# Altium schematic sheet SWITCHES.SchDoc(SWITCHES)
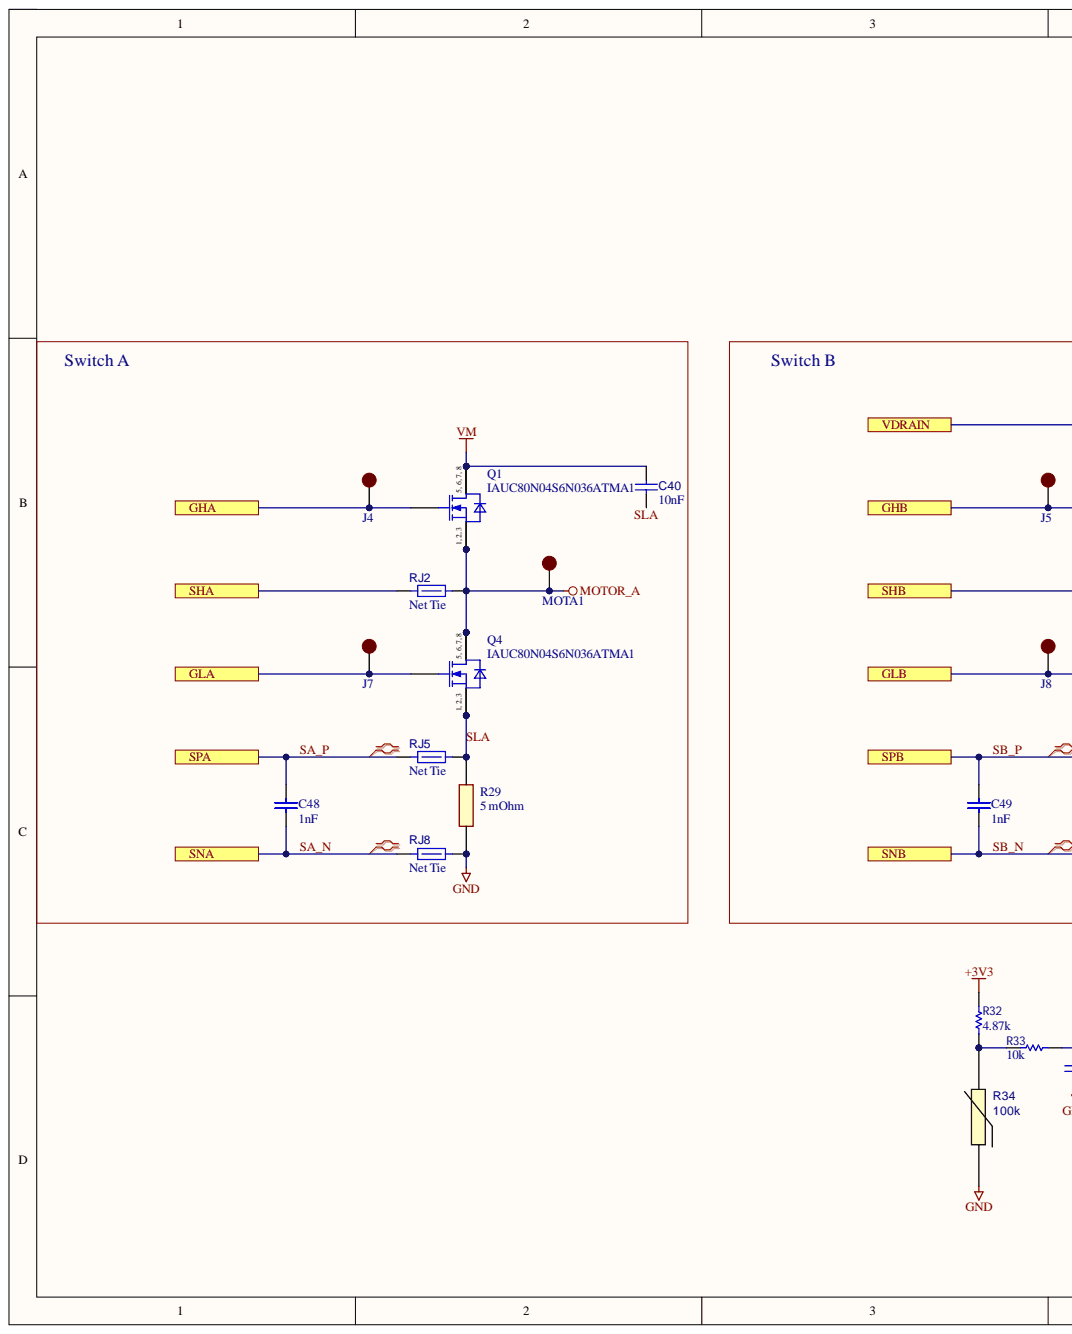
[diagram p0: SWITCHES.SchDoc, left]
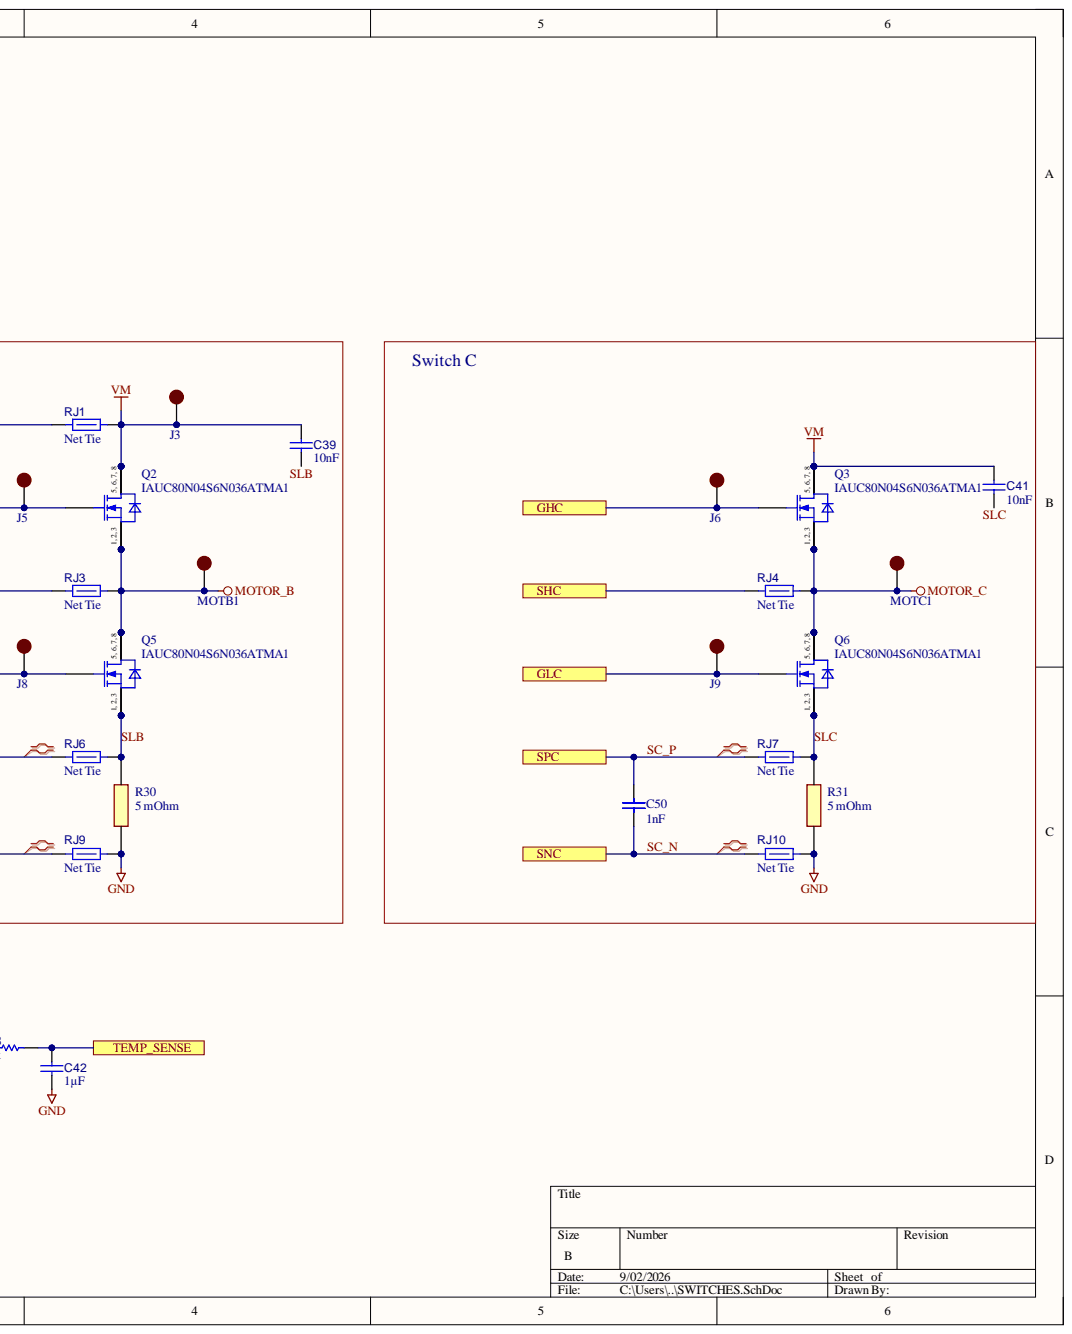
[diagram p1: SWITCHES.SchDoc, right]

## Parts
C39  10nF  [CAPC3216X190X55ML30T25]
C40  10nF  [CAPC3216X190X55ML30T25]
C41  10nF  [CAPC3216X190X55ML30T25]
C42  1µF  [0603_Capacitor]
C48  1nF  [C-0402]
C49  1nF  [C-0402]
C50  1nF  [C-0402]
J3  5000 TP  [FP-5000-MFG]  (value not drawn)
J4  5000 TP  [FP-5000-MFG]  (value not drawn)
J5  5000 TP  [FP-5000-MFG]  (value not drawn)
J6  5000 TP  [FP-5000-MFG]  (value not drawn)
J7  5000 TP  [FP-5000-MFG]  (value not drawn)
J8  5000 TP  [FP-5000-MFG]  (value not drawn)
J9  5000 TP  [FP-5000-MFG]  (value not drawn)
MOTA1  5000 TP  [FP-5000-MFG]  (value not drawn)
MOTB1  5000 TP  [FP-5000-MFG]  (value not drawn)
MOTC1  5000 TP  [FP-5000-MFG]  (value not drawn)
Q1  IAUC80N04S6N036ATMA1  [INF-PG-TDSON-8-33-V]
Q2  IAUC80N04S6N036ATMA1  [INF-PG-TDSON-8-33-V]
Q3  IAUC80N04S6N036ATMA1  [INF-PG-TDSON-8-33-V]
Q4  IAUC80N04S6N036ATMA1  [INF-PG-TDSON-8-33-V]
Q5  IAUC80N04S6N036ATMA1  [INF-PG-TDSON-8-33-V]
Q6  IAUC80N04S6N036ATMA1  [INF-PG-TDSON-8-33-V]
R29  5 mOhm  [RESC6432X80N]
R30  5 mOhm  [RESC6432X80N]
R31  5 mOhm  [RESC6432X80N]
R32  value 4.87k  [R-0402]  (hidden comment: Resistor 4.87k)
R33  value 10k  [R-0402]  (hidden comment: Resistor 10k)
R34  100k  [0603_RESISTOR]
RJ1  Net Tie  [NetTie, SMD, 10mil, FIXED]
RJ2  Net Tie  [NetTie, SMD, 10mil, FIXED]
RJ3  Net Tie  [NetTie, SMD, 10mil, FIXED]
RJ4  Net Tie  [NetTie, SMD, 10mil, FIXED]
RJ5  Net Tie  [NetTie, SMD, 10mil, FIXED]
RJ6  Net Tie  [NetTie, SMD, 10mil, FIXED]
RJ7  Net Tie  [NetTie, SMD, 10mil, FIXED]
RJ8  Net Tie  [NetTie, SMD, 10mil, FIXED]
RJ9  Net Tie  [NetTie, SMD, 10mil, FIXED]
RJ10  Net Tie  [NetTie, SMD, 10mil, FIXED]

## Ports
GHA, GHB, GHC, GLA, GLB, GLC, SHA, SHB, SHC, SNA, SNB, SNC, SPA, SPB, SPC, TEMP_SENSE, VDRAIN

## Nets
+3V3: R32.2
GND: C42.2 R29.1 R30.1 R31.1 R34.1 RJ8.2 RJ9.2 RJ10.2
MOTOR_A: MOTA1.1 Q1.1 Q1.2 Q1.3 Q4.5 Q4.6 Q4.7 Q4.8 RJ2.2
MOTOR_B: MOTB1.1 Q2.1 Q2.2 Q2.3 Q5.5 Q5.6 Q5.7 Q5.8 RJ3.2
MOTOR_C: MOTC1.1 Q3.1 Q3.2 Q3.3 Q6.5 Q6.6 Q6.7 Q6.8 RJ4.2
VM: C39.2 C40.2 C41.2 J3.1 Q1.5 Q1.6 Q1.7 Q1.8 Q2.5 Q2.6 Q2.7 Q2.8 Q3.5 Q3.6 Q3.7 Q3.8 RJ1.2
GHA: J4.1 Q1.4 ; port GHA
GHB: J5.1 Q2.4 ; port GHB
GHC: J6.1 Q3.4 ; port GHC
GLA: J7.1 Q4.4 ; port GLA
GLB: J8.1 Q5.4 ; port GLB
GLC: J9.1 Q6.4 ; port GLC
SA_N: C48.2 RJ8.1 ; port SNA
SA_P: C48.1 RJ5.1 ; port SPA
SB_N: C49.2 RJ9.1 ; port SNB
SB_P: C49.1 RJ6.1 ; port SPB
SC_N: C50.2 RJ10.1 ; port SNC
SC_P: C50.1 RJ7.1 ; port SPC
SHA: RJ2.1 ; port SHA
SHB: RJ3.1 ; port SHB
SHC: RJ4.1 ; port SHC
SLA: C40.1 Q4.1 Q4.2 Q4.3 R29.2 RJ5.2
SLB: C39.1 Q5.1 Q5.2 Q5.3 R30.2 RJ6.2
SLC: C41.1 Q6.1 Q6.2 Q6.3 R31.2 RJ7.2
TEMP_SENSE: C42.1 R33.2 ; port TEMP_SENSE
VDRAIN: RJ1.1 ; port VDRAIN
NetR32_1: R32.1 R33.1 R34.2

## Library data of the parts
C39-C41 = CMP-001-00246-1: Ceramic Capacitor, Multilayer, Ceramic, 630V, 5% +Tol, 5% -Tol, C0G, 30ppm/Cel TC, 0.01uF, Surface Mount, 1206; Manufacturer: YAGEO; Manufacturer PN: CC1206JFNPOZBN103; Type: Capacitor C0G; Component Kind: Standard; ComponentLink1Description: Datasheet; ComponentLink1URL: https://www.yageo.com/en/Chart/Download/pdf/CC1206JFNPOZBN103; Library Name: BLDC_Symbols.SchLib; Package: 1206; Supplier 1: Digikey; Supplier Part Number 1: 13-CC1206JFNPOZBN103CT-ND; Tolerance: 5%; Value: 10nF; Voltage Rating: 630V
C42 = CMP-001-00209-4: 1 µF ±10% 25V Ceramic Capacitor X7R 0603 (1608 Metric); Manufacturer: Samsung Electro-Mechanics; Manufacturer PN: CL10B105KA8NNNC; Component Kind: Standard; ComponentLink1Description: Datasheet; ComponentLink1URL: https://mm.digikey.com/Volume0/opasdata/d220001/medias/docus/3644/CL10B105KA8NNNC_spec.pdf; Library Name: BLDC_Symbols.SchLib; Package: 0603; Supplier 1: Digikey; Supplier Part Number 1: 1276-1184-1-ND; Tolerance: 10%; Type: Capacitor X7R; Value: 1µF; Voltage Rating: 25V
C48-C50 = CAP, 1nF 0603 X7R: 1000 pF ±10% 50V Ceramic Capacitor X7R 0402 (1005 Metric); Manufacturer: YAGEO; Manufacturer PN: CC0402KRX7R9BB102; Tolerance: 5%; Component Kind: Standard; ComponentLink1Description: Datasheet; ComponentLink1URL: https://www.mouser.com/datasheet/3/508/1/KEM_C1002_X7R_SMD.pdf; Library Name: BLDC_Symbols.SCHLIB; Package: 0402; Supplier 1: Digikey; Supplier Part Number 1: 311-1036-1-ND; Type: Capacitor X7R; Value: 1nF; Voltage Rating: 50V
J3-J9 MOTA1 MOTB1 MOTC1 = CMP-00030-00018-2: Keystone 5000 Test Point ultra compact; Manufacturer PN: 5000; Component Kind: Standard; ComponentLink1Description: Datasheet; ComponentLink1URL: https://www.keyelco.com/userAssets/file/K75p62.pdf; Library Name: BLDC_Symbols.SchLib; Manufacturer: Keystone; Package: TH; Supplier 1: Digikey; Supplier Part Number 1: 36-5000-ND; Type: Test Point; Value: 5000 TP
Q1-Q6 = CMP-004-00064-1: Insulated-Gate Field-Effect Transistor (IGFET), N-Channel, Enhancement, Body Diode, Pin 1 Source, 2 Source, 3 Source, 4 Gate, 5 Drain, 6 Drain, 7 Drain, 8 Drain, 8 Pins; Manufacturer: Infineon Technologies; Current: 80A; Power: 50W; Type: Power MOSFET; Voltage Rating: 40V; Component Kind: Standard; ComponentLink1Description: Datasheet; ComponentLink1URL: https://www.infineon.com/assets/row/public/documents/10/49/infineon-iauc80n04s6n036-datasheet-en.pdf?fileId=5546d4626afcd350016b1c881e60109f; Library Name: BLDC_Symbols.SchLib; Manufacturer PN: IAUC80N04S6N036ATMA1; Package: DFN; Supplier 1: Digikey; Supplier Part Number 1: 448-IAUC80N04S6N036ATMA1CT-ND; Value: IAUC80N04S6N036ATMA1
R29-R31 = CMP-009-00332-1: Current Sense Resistors - SMD 0.005ohms 1% 75PPM; Manufacturer: Stackpole Electronics; Manufacturer PN: CSNL2512FT5L00; Power: 3W; Component Kind: Standard; ComponentLink1Description: Datasheet; ComponentLink1URL: https://www.seielect.com/catalog/SEI-CSNL.pdf; Library Name: BLDC_Symbols.SchLib; Package: 2512; Supplier 1: Digikey; Supplier Part Number 1: CSNL2512FT5L00CT-ND; Tolerance: 1%; Type: Shunt Resistor; Value: 5 mOhm
R32 = Resistor 4.87k: 4.87 kOhms ±1% 0.063W, 1/16W Chip Resistor 0402 (1005 Metric) Moisture Resistant Thick Film; Manufacturer: YAGEO; Manufacturer PN: RC0402FR-074K87L; Power: 0.0625; Component Kind: Standard; ComponentLink1Description: Datasheet; Library Name: BLDC_Symbols.SchLib; Package: 0402; Supplier 1: Digikey; Supplier Part Number 1: YAG3175CT-ND; Tolerance: 1%; Type: Resistor
R33 = Resistor 10k: 10 kOhms ±5% 0.063W, 1/16W Chip Resistor 0402 (1005 Metric) Moisture Resistant Thick Film; Manufacturer: YAGEO; Manufacturer PN: RC0402JR-0710KL; Power: 0.0625; Component Kind: Standard; ComponentLink1Description: Datasheet; Library Name: BLDC_Symbols.SchLib; Package: 0402; Supplier 1: Digikey; Supplier Part Number 1: 311-10KJRCT-ND; Tolerance: 5%; Type: Resistor
R34 = CMP-009-00349-2: SMD NTC, 0603, 100K, 4000; Manufacturer: Eaton; Manufacturer PN: NT06105F4000B1F; Power: 200 mW; Tolerance: 1%; Component Kind: Standard; ComponentLink1Description: Datasheet; ComponentLink1URL: https://www.eaton.com/content/dam/eaton/products/electronic-components/resources/data-sheet/eaton-nt-surface-mount-ntc-thermistor-data-sheet-elx1110-en.pdf; Library Name: BLDC_Symbols.SchLib; Package: 0603; Supplier 1: Digikey; Supplier Part Number 1: 283-NT06105F4000B1FCT-ND; Type: Thermistor; Value: 100k
RJ1-RJ10 = CMP-009-00116-3: Net Tie 10x8mil; Component Kind: Net Tie; ComponentLink1Description: Datasheet; Library Name: BLDC_Symbols.SCHLIB; Type: Pad; Value: Net Tie
